# Altium schematic sheet IR_Remote_LEDs.SchDoc
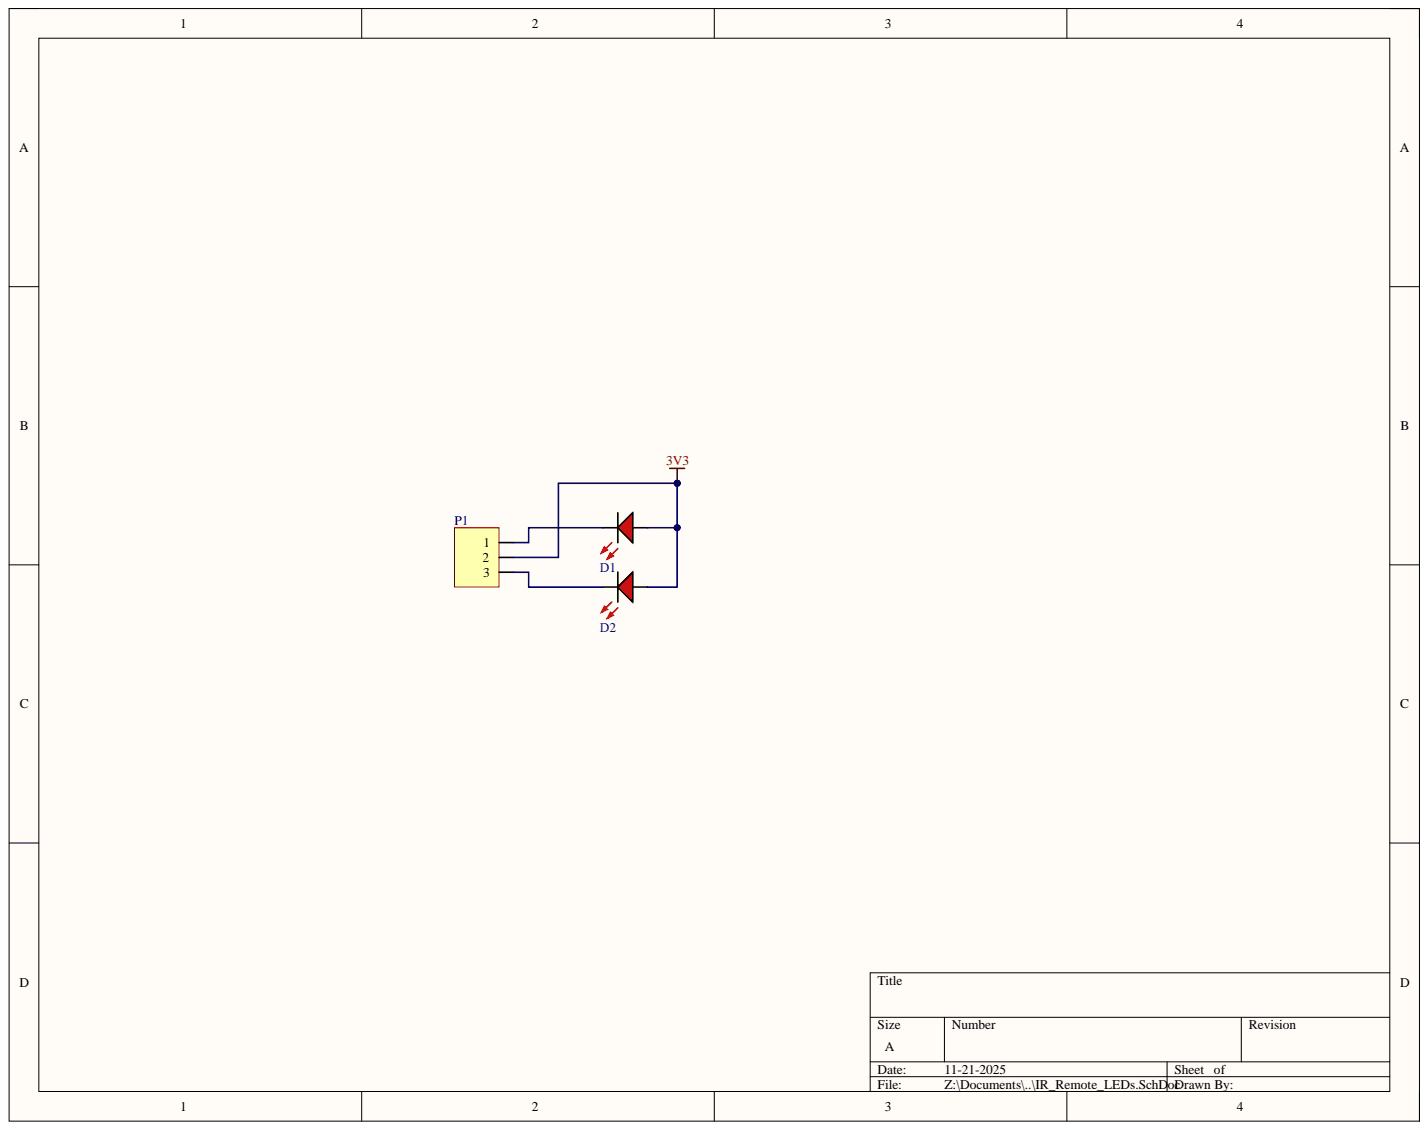
[diagram p0: IR_Remote_LEDs.SchDoc]

## Parts
D1  LED Red  [LED5MM]  (value not drawn)
D2  LED Red  [LED5MM]  (value not drawn)
P1  Header, 3-Pin  [HDR1X3S]  (value not drawn)

## Nets
3V3: D1.A D2.A P1.2
NetD1_K: D1.K P1.1
NetD2_K: D2.K P1.3

## Library data of the parts
D1 D2 = LED_5MM_RED: LED 5mm Red; Manufacturer: Generic Components; Class I: Optoelectronic; Class II: LED; Component Kind: Standard; Farnell PN: 1581239; LCSC: C2286; Library Name: MyLibrary.IntLib; Published: 8-Jun-2000; Revision: July-2002: Re-released for DXP Platform.; Publisher: Altium Limited
P1 = Header 1x3: Component Kind: Standard; Description: Header, 3-Pin; LatestRevisionDate: 17-Jul-2002; LatestRevisionNote: Re-released for DXP Platform.; Library Name: MyLibrary.IntLib; Signal Integrity: Connector; Publisher: Altium Limited
